# Altium schematic sheet basic_bot_esq.SchDoc
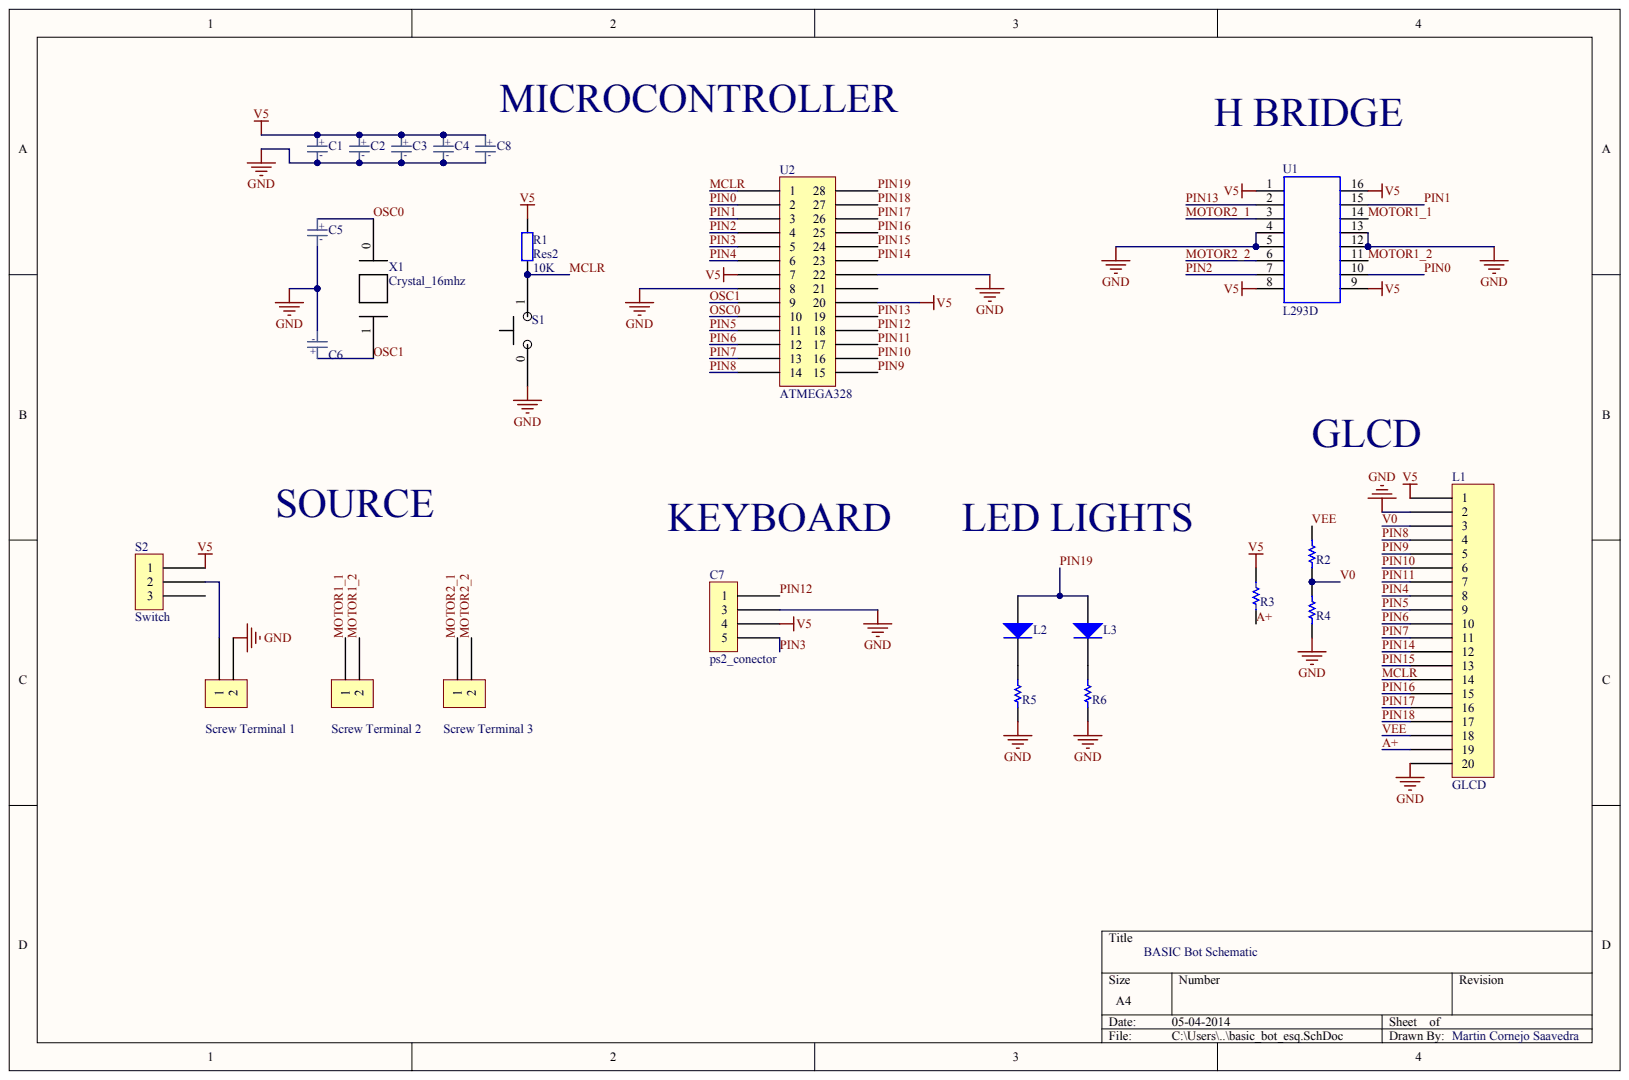
[diagram p0: basic_bot_esq.SchDoc]

## Parts
C1  CONDENSADOR_GENERICO  (value not drawn)
C2  CONDENSADOR_GENERICO  (value not drawn)
C3  CONDENSADOR_GENERICO  (value not drawn)
C4  CONDENSADOR_GENERICO  (value not drawn)
C5  CONDENSADOR_GENERICO  (value not drawn)
C6  CONDENSADOR_GENERICO  (value not drawn)
C7  ps2_conector
C8  CONDENSADOR_GENERICO  (value not drawn)
L1  GLCD
L2  LED_COMUN  (value not drawn)
L3  LED_COMUN  (value not drawn)
R1  Res2  value 10K
R2  resistencia_axial_04  (value not drawn)
R3  resistencia_axial_04  (value not drawn)
R4  resistencia_axial_04  (value not drawn)
R5  resistencia_axial_04  (value not drawn)
R6  resistencia_axial_04  (value not drawn)
S1  pulsador_rst  (value not drawn)
S2  Switch
Screw_Terminal_1  terminal_2pin  (value not drawn)
Screw_Terminal_2  terminal_2pin  (value not drawn)
Screw_Terminal_3  terminal_2pin  (value not drawn)
U1  L293D
U2  ATMEGA328
X1  Crystal_16mhz

## Nets
GND: C1.1 C2.1 C3.1 C4.1 C5.1 C6.1 C7.3 C8.1 L1.2 L1.20 R4.1 R5.1 R6.1 S1.0 Screw_Terminal_1.2 U1.4 U1.5 U1.12 U1.13 U2.8 U2.22
V5: C1.2 C2.2 C3.2 C4.2 C7.4 C8.2 L1.1 R1.2 R3.2 S2.1 U1.1 U1.8 U1.9 U1.16 U2.7 U2.20
A+: L1.19 R3.1
MCLR: L1.14 R1.1 S1.1 U2.1
MOTOR1_1: Screw_Terminal_2.1 U1.14
MOTOR1_2: Screw_Terminal_2.2 U1.11
MOTOR2_1: Screw_Terminal_3.1 U1.3
MOTOR2_2: Screw_Terminal_3.2 U1.6
OSC0: C5.2 U2.10 X1.0
OSC1: C6.2 U2.9 X1.1
PIN0: U1.10 U2.2
PIN1: U1.15 U2.3
PIN2: U1.7 U2.4
PIN3: C7.5 U2.5
PIN4: L1.8 U2.6
PIN5: L1.9 U2.11
PIN6: L1.10 U2.12
PIN7: L1.11 U2.13
PIN8: L1.4 U2.14
PIN9: L1.5 U2.15
PIN10: L1.6 U2.16
PIN11: L1.7 U2.17
PIN12: C7.1 U2.18
PIN13: U1.2 U2.19
PIN14: L1.12 U2.23
PIN15: L1.13 U2.24
PIN16: L1.15 U2.25
PIN17: L1.16 U2.26
PIN18: L1.17 U2.27
PIN19: L2.1 L3.1 U2.28
V0: L1.3 R2.1 R4.2
VEE: L1.18 R2.2
NetL2_2: L2.2 R5.2
NetL3_2: L3.2 R6.2
NetS2_2: S2.2 Screw_Terminal_1.1

Not connected: S2 3; U2 21

## Library data of the parts
C1-C8 L1-L3 R1-R6 S1 S2 Screw_Terminal_1-Screw_Terminal_3 U1 U2 X1 = (no symbol name)
  R1: Published: 8-Jun-2000; LatestRevisionDate: 17-Jul-2002; LatestRevisionNote: Re-released for DXP Platform.; PackageReference: AXIAL-0.4; PackageDescription: Axial Device, Thru-Hole; 2 Leads; 0.4 in Pin Spacing; Publisher: Altium Limited
